# Altium schematic sheet SMPS.SchDoc
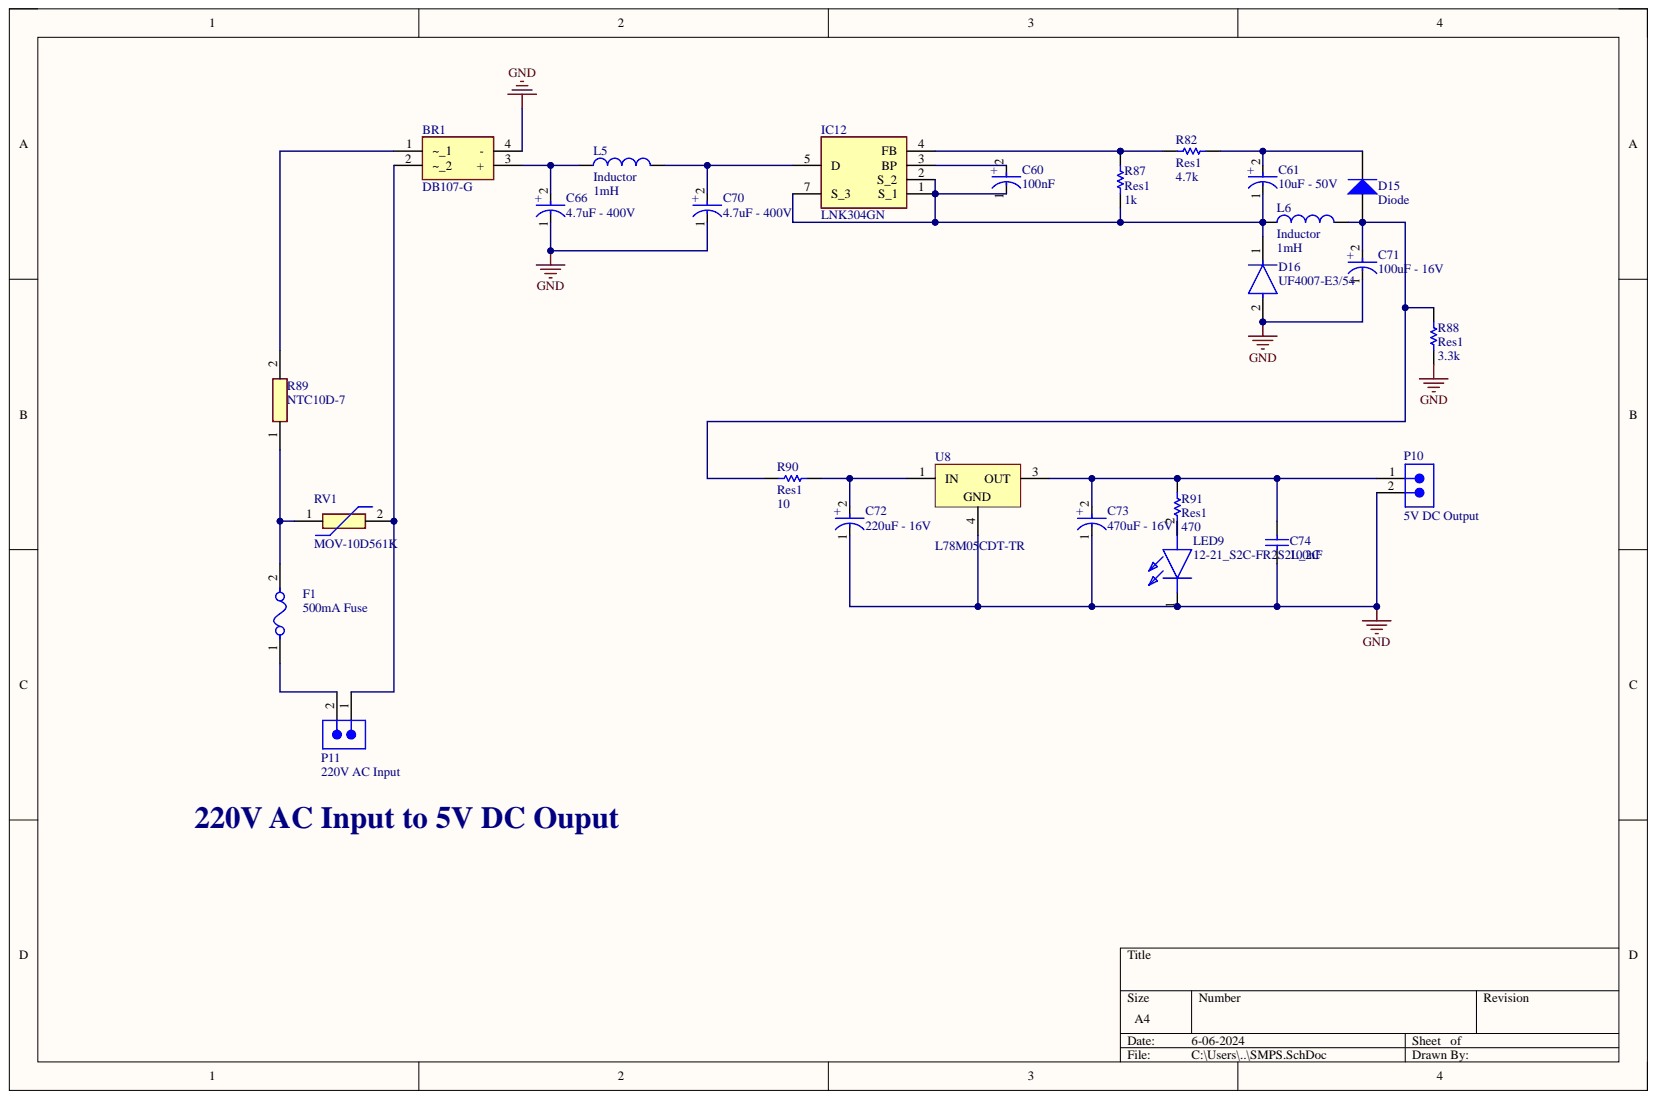
[diagram p0: SMPS.SchDoc]

## Parts
BR1  DB107-G  [DIP815W55P510L850H340Q4N]
C60  100nF  [FP-SC-MFG]
C61  10uF - 50V  Capacitance: 10uF  [FP-FKD-MFG]
C66  4.7uF - 400V  Capacitance: 4.7uF  [FP-RAD-TH-D_10_0_5-L_16_1-MFG]
C70  4.7uF - 400V  Capacitance: 4.7uF  [FP-RAD-TH-D_10_0_5-L_16_1-MFG]
C71  100uF - 16V  [FP-FPB-MFG]
C72  220uF - 16V  Capacitance: 220uF  [FP-RAD-TH-D_8_0_5-L_11_5-MFG]
C73  470uF - 16V  Capacitance: 470uF  [FP-FPF-MFG]
C74  100nF  [WCAP-ASNP_D4H5.5]
D15  Diode  [SMC]
D16  UF4007-E3/54  [FP-UF4007-MFG]
F1  500mA Fuse  [FP-0154-MFG]
IC12  LNK304GN  [G-PACKAGE(SMD-8B)_1]
L5  Inductor  value 1mH  [0402-A]
L6  Inductor  value 1mH  [0402-A]
LED9  12-21_S2C-FR2S2L_2C  [1221S2CFR2S2L2C]
P10  5V DC Output  [620302124022]
P11  220V AC Input  [620302124022]
R82  Res1  value 4.7k  [AXIAL-0.3]
R87  Res1  value 1k  [AXIAL-0.3]
R88  Res1  value 3.3k  [AXIAL-0.3]
R89  NTC10D-7  [NTC10D7]
R90  Res1  value 10  [AXIAL-0.3]
R91  Res1  value 470  [AXIAL-0.3]
RV1  MOV-10D561K  [MOV10D561K]
U8  L78M05CDT-TR  [TO-252_L]

## Nets
GND: BR1.4(-) C66.1 C70.1 C71.1 C72.1 C73.1 C74.1 D16.2 LED9.1 P10.2 R88.1 U8.4
NetBR1_1: BR1.1(~_1) R89.2
NetBR1_2: BR1.2(~_2) P11.1 RV1.2
NetBR1_3: BR1.3(+) C66.2 L5.1
NetC60_1: C60.1 C61.1 D16.1 IC12.1(S_1) IC12.2(S_2) IC12.7(S_3) L6.1 R87.1
NetC60_2: C60.2 IC12.3(BP)
NetC61_2: C61.2 D15.2 R82.2
NetC70_2: C70.2 IC12.5(D) L5.2
NetC71_2: C71.2 D15.1 L6.2 R88.2 R90.2
NetC72_2: C72.2 R90.1 U8.1
NetC73_2: C73.2 C74.2 P10.1 R91.1 U8.3
NetF1_1: F1.1 P11.2
NetF1_2: F1.2 R89.1 RV1.1
NetIC12_4: IC12.4(FB) R82.1 R87.2
NetLED9_2: LED9.2 R91.2

## Library data of the parts
BR1 = DB107-G: Bridge Rectifier; Manufacturer_Name: Comchip Technology; Arrow Part Number: DB107-G; Arrow Price/Stock: https://www.arrow.com/en/products/db107-g/comchip-technology?region=nac; Component Kind: Standard; Datasheet Link: https://datasheet.datasheetarchive.com/originals/distributors/Datasheets-DGA18/326891.pdf; Height: 3.4mm; Manufacturer_Part_Number: DB107-G; Mouser Part Number: 750-DB107-G; Mouser Price/Stock: https://www.mouser.co.uk/ProductDetail/Comchip-Technology/DB107-G?qs=2qJf6qQ4IOJFd%2FXSP%252BNqrw%3D%3D
C60 = CMP-05427-000047-1: CAP ALUM 100UF 20% 10V SMD; Life (Hours): 1000Hour; Ripple Current: 50mA; Capacitance: 100uF; Case/Package: Radial; Component Kind: Standard; Construction: Cylindrical; Contact Plating: Tin; Diameter: 5mm; Height: 5.4mm; Height - Seated (Max): 0.213inch; Lead Free: Lead Free; Length: 5.5mm; Library Name: Altium Content Vault; Max Operating Temperature: 85°C; Min Operating Temperature: -40°C; Mount: Surface Mount; Package Quantity: 1; Packaging: Tape and Reel; Radiation Hardening: No; Ripple Current (AC): 50mA; RoHS Compliant: Yes; Series: S; Termination: SMD/SMT; Tolerance: 20%; Voltage Rating: 10V; Voltage Rating (DC): 10V
C61 = CMP-2000-06207-2: CAP ALUM 10UF 20% 50V SMD; ESR (Equivalent Series Resistance): 880mR; Lead Diameter: 0.65mm; Leakage Current: 3uA; Life (Hours): 2000Hour; Polarity: Polar; Ripple Current: 165mA; Case/Package: Radial; Component Kind: Standard; Construction: Cylindrical; Contact Plating: Tin; Diameter: 6.3mm; Height: 5.8mm; Height - Seated (Max): 0.24inch; Impedance: 880mR; Lead Free: Lead Free; Lead Pitch: 1.8mm; Length: 5.8mm; Library Name: Altium Content Vault; Max Operating Temperature: 105°C; Min Operating Temperature: -55°C; Mount: Surface Mount; Number of Pins: 2; Package Quantity: 1000; Packaging: Tape and Reel; Radiation Hardening: No; REACH SVHC: No SVHC; Ripple Current (AC): 165mA; RoHS Compliant: Yes; Series: FK; Size: 5.8mm; Termination: SMD/SMT; Tolerance: 20%; Voltage: 50V; Voltage Rating: 50V; Voltage Rating (DC): 50V; Width: 7.8mm
C66 C70 = CMP-05427-000266-1: Aluminum Electrolytic Capacitors (Radial Lead Type) 4.7uF ±20% 400V; Dielectric Material: Aluminium; Dissipation Factor: 0.2%; Lead Diameter: 0.6mm; Lead Length: 17mm; Lead/Base Style: Straight; Leakage Current: 122.8uA; Life (Hours): 2000Hour; Polarity: Polar; Ripple Current: 72mA; Case/Package: Radial; Component Kind: Standard; Construction: RADIAL; Diameter: 10mm; Height: 16mm; Height - Seated (Max): 0.669inch; Lead Pitch: 5mm; Length: 16mm; Library Name: Altium Content Vault; Max Operating Temperature: 85°C; Min Operating Temperature: -25°C; Mount: Through Hole; Number of Pins: 2; Package Quantity: 200; Packaging: Bulk; Radiation Hardening: No; REACH SVHC: No SVHC; Ripple Current (AC): 72mA; RoHS Compliant: Yes; Series: M; Termination: Radial; Tolerance: 20%; Voltage: 400V; Voltage Rating: 400V; Voltage Rating (DC): 400V
C71 = CMP-00287-07742837-1: EEEFP1C100AR Aluminum Electrolytic Capacitor, 10 uF, 16 V, ± 20%, 2000 hours @ 105°C, Radial Can - SMD; Capacitance: 10µF; Dissipation Factor: 16%; ESR (Equivalent Series Resistance): 850mΩ; Leakage Current: 3µA; Material: Aluminium; Ripple Current: 160mA; Case/Package: Radial; Component Kind: Standard; Contact Plating: Tin; Diameter: 4mm; Features: High Temperature; Height: 5.8mm; Height - Seated (Max): 5.7912mm; Lead Free: Lead Free; Lead Pitch: 1mm; Length: 6.1mm; Library Name: Altium Content Vault; Life (Hours): 2000hours; Lifecycle Status: Production; Max Operating Temperature: 105°C; Min Operating Temperature: -55°C; Mount: PCB, Surface Mount; NexarPartId: 7742837; Number of Pins: 2; Packaging: Cut Tape; Radiation Hardening: No; REACH SVHC: No SVHC; Ripple Current (AC): 160mA; RoHS: Compliant; Schedule B: 8532220020, 8532220020; Termination: SMD/SMT; Tolerance: 20%; Voltage: 16V; Voltage Rating: 16V; Voltage Rating (DC): 16V
C72 = CMP-05427-002014-1: Aluminum Electrolytic Capacitors (Radial Lead Type) AEC-Q200 Qualified 220uF ±20% 16V; Impedance: 117mR; Lead Diameter: 0.6mm; Life (Hours): 2000Hour; Polarity: Polar; Ripple Current: 555mA; Case/Package: Radial; Component Kind: Standard; Diameter: 8mm; Height: 11.5mm; Height - Seated (Max): 0.492inch; Lead Pitch: 5mm; Length: 11mm; Library Name: Altium Content Vault; Max Operating Temperature: 105°C; Min Operating Temperature: -55°C; Mount: Through Hole; Package Quantity: 1000; Packaging: Ammo Pack; Radiation Hardening: No; Ratings: AEC-Q200; RoHS Compliant: Yes; Series: FC; Termination: Radial; Tolerance: 20%; Voltage Rating: 16V; Voltage Rating (DC): 16V
C73 = CMP-05427-002187-1: CAP ALUM 470UF 20% 16V SMD; ESR (Equivalent Series Resistance): 80mR; Leakage Current: 75.2uA; Life (Hours): 2000Hour; Material: Aluminium; Ripple Current: 850mA; Case/Package: Radial; Component Kind: Standard; Construction: Cylindrical; Contact Plating: Tin; Diameter: 8mm; Height: 10.2mm; Height - Seated (Max): 0.402inch; Lead Free: Lead Free; Lead Pitch: 3.1mm; Length: 10.5mm; Library Name: Altium Content Vault; Max Operating Temperature: 105°C; Min Operating Temperature: -55°C; Mount: Surface Mount; Number of Pins: 2; Package Quantity: 1; Packaging: Tape and Reel; Radiation Hardening: No; Reach SVHC Compliant: Yes; Ripple Current (AC): 850mA; RoHS Compliant: Yes; Series: FP; Termination: SMD/SMT; Tolerance: 20%; Voltage: 16V; Voltage Rating: 16V; Voltage Rating (DC): 16V; Width: 8.3mm
C74 = CMP-03913-53758718-1: 865250640001 WCAP-ASNP Aluminum Electrolytic Capacitors; Impedance: 20.6814Ω; Insulation Resistance: 16.6667MΩ; Leakage Current: 10µA; Life (Hours): 2000hours; Polarity: Polar; Ripple Current: 1mA; Tolerance: 20%; Voltage Rating: 50V; Capacitance: 100nF; Case/Package: Radial; Component Kind: Standard; Diameter: 4mm; Height: 5.5mm; Height - Seated (Max): 5.5118mm; Length: 5.5mm; Library Name: Altium Content Vault; Max Operating Temperature: 85°C; Min Operating Temperature: -40°C; Mount: Surface Mount; NexarPartId: 53758718; Packaging: Tape & Reel (TR); REACH SVHC: Unknown; Ripple Current (AC): 1mA; RoHS: Compliant; Voltage Rating (DC): 50V; Width: 4.3mm
D15 = Diode: Default Diode; Code_JEDEC: DO-214-AB; Component Kind: Standard; LatestRevisionDate: 17-Jul-2002; LatestRevisionNote: Re-released for DXP Platform.; Library Name: Miscellaneous Devices.IntLib; PackageDescription: DO-214-AB/SMC; 2 C-Bend Leads; Body 7.9 x 5.9 mm, inc. leads (LxW); PackageReference: SMC; PackageVersion: Sep-1996; Published: 8-Jun-2000; Signal Integrity: Diode; Simulation: DIODE; Publisher: Altium Limited
D16 = CMP-02540-000043-1: DIODE GEN PURP 1KV 1A DO204AL; Manufacturer: Vishay; Capacitance: 17pF; Max Junction Temp: +150°C; Max Repetitive Reverse Voltage: 1000V; Max Surge Forward Current: 30A; Peak Reverse Current: 10uA; Value: 17pF; Automotive: No; Category: Diode; Component Kind: Standard; ComponentLink2Description: Datasheet; ComponentLink2URL: http://www.vishay.com/docs/88755/uf4001.pdf; Device Class L1: Discrete Semiconductors; Device Class L2: Diodes; Device Class L3: Rectifier Diodes; Digikey Description: DIODE GEN PURP 1KV 1A DO204AL; Forward Current: 1A; Height: 2.7mm; Ipc Land Pattern Name: DIOPA1088W105L520D270; Lead Free: Yes; Library Name: Altium Content Vault; Manufacturer Part Number: UF4007-E3/54; Mouser Description: Rectifiers Vr/1000V Io/1A; Octopart: https://octopart.com/uf4007-e3%2F54-vishay-42333894; Package: UF4007; RoHS: TRUE; Temperature: -55°C to +150°C; Temperature Range High: +150°C; Temperature Range Low: -55°C
F1 = CMP-08605-000021-1: FUSE BOARD MNT 500MA 125VAC/VDC; Manufacturer: Littelfuse; Manufacturer Part Number: 0154.500DRT; Fuse Blow Speed: Slow; Fuse Current: 0.5A; Fuse I2T: 0.24A2Sec; Max Fuse Ac Voltage: 125V; Automotive: No; Category: Fuse; Component Kind: Standard; ComponentLink2Description: Datasheet; ComponentLink2URL: https://www.littelfuse.com/~/media/electronics/datasheets/fuses/littelfuse_fuse_154_154t_154l_154tl_datasheet.pdf.pdf; Device Class L1: Circuit Protection; Device Class L2: Fuses; Device Class L3: unset; Digikey Description: FUSE BOARD MNT 500MA 125VAC/VDC; Height: 3.81mm; Lead Free: Yes; Library Name: Altium Content Vault; Max Fuse Dc Voltage: 125V; Mouser Description: Surface Mount Fuses Fuseblck w/ fuse .5A OMNI BLOK 154T; Octopart: https://octopart.com/0154.500drt-littelfuse-565915; Package: 0154; RoHS: TRUE; Temperature: -55°C to +125°C; Temperature Range High: +125°C; Temperature Range Low: -55°C
IC12 = LNK304GN: Integrated Circuit; Manufacturer_Name: Power Integrations; Component Kind: Standard; Datasheet Link: https://www.power.com/sites/default/files/product-docs/lnk302_304-306.pdf; Manufacturer_Part_Number: LNK304GN; Mouser Part Number: 869-LNK304GN; Mouser Price/Stock: https://www.mouser.co.uk/ProductDetail/Power-Integrations/LNK304GN?qs=Jph8NoUxIfWAnpfQOKd5ww%3D%3D
L5 L6 = Inductor: Code_IEC: 1005; Value: 1mH; Code_JEITA: 0402; Component Kind: Standard; Description: Inductor; LatestRevisionDate: 29-May-2009; LatestRevisionNote: IPC-7351 Footprint Added.; Library Name: Miscellaneous Devices.IntLib; PackageDescription: Chip Inductor; PackageReference: 0402-A; Published: 8-Jun-2000; Signal Integrity: Inductor; Simulation: INDUCTOR; Publisher: Altium Limited
LED9 = 12-21_S2C-FR2S2L_2C: LED; Manufacturer_Name: Everlight; Manufacturer_Part_Number: 12-21/S2C-FR2S2L/2C; Component Kind: Standard; Datasheet Link: https://datasheet.lcsc.com/szlcsc/1901141904_Everlight-Elec-12-21-S2C-FR2S2L-2C_C183856.pdf; Height: 2.2mm; Simulation: L_Inductor_Default
P10 P11 = CMP-1502-00660-2: NexarPartId: 18818242; Connector Type: Male Pin; Contact Resistance: 20mΩ; Current Rating: 2A; Gender: Male; Insulation: Insulated; Insulation Resistance: 1GΩ; Number of Contacts: 2; Operating Supply Voltage: 100V; Pitch: 2mm; Voltage Rating: 125V; Color: Beige, White; Component Kind: Standard; Contact Gender: Male; Contact Material: Copper; Contact Mating Length: 3.5052mm; Contact Plating: Tin; Flammability Rating: UL94 V-0; Height: 6.6mm; Housing Material: Nylon; Lead Pitch: 2mm; Length: 5mm; Library Name: Altium Content Vault; Max Operating Temperature: 85°C; Min Operating Temperature: -40°C; Mount: Surface Mount; Number of Pins: 2; Number of Positions: 2; Number of Rows: 1; Orientation: Vertical; Packaging: Tape and Reel; REACH SVHC: Unknown; RoHS: Compliant; Termination: SMD/SMT; Width: 7.9mm
R82 R87 R88 R90 R91 = Res1: Resistor; Component Kind: Standard; LatestRevisionDate: 17-Jul-2002; LatestRevisionNote: Re-released for DXP Platform.; Library Name: Miscellaneous Devices.IntLib; PackageDescription: Axial Device, Thru-Hole; 2 Leads; 0.3 in Pin Spacing; PackageReference: AXIAL-0.3; Published: 8-Jun-2000; Signal Integrity: Res; Simulation: RESISTOR; Publisher: Altium Limited
R89 = NTC10D-7: Resistor; Manufacturer_Name: NTC; Component Kind: Standard; Datasheet Link: https://www.lcsc.com/datasheet/lcsc_datasheet_2403080959_RUILON-Shenzhen-Ruilongyuan-Elec-NTC10D-7_C9176.pdf; Height: 13mm; Manufacturer_Part_Number: NTC10D-7
RV1 = MOV-10D561K: Varistor; Manufacturer_Name: Bourns; Arrow Part Number: MOV-10D561K; Arrow Price/Stock: null?region=nac; Component Kind: Standard; Datasheet Link: https://www.bourns.com/docs/Product-Datasheets/MOV10D.pdf; Height: 15.5mm; Manufacturer_Part_Number: MOV-10D561K; Mouser Part Number: 652-MOV-10D561K; Mouser Price/Stock: https://www.mouser.co.uk/ProductDetail/Bourns/MOV-10D561K?qs=lgttKnAd%252B2ArrqGQgWwr6w%3D%3D
U8 = CMP-0244-00178-1: Positive Voltage Regulator, 5V, 3-Pin DPAK, Tape and Reel; Component Kind: Standard; ComponentLink1Description: Manufacturer URL; ComponentLink1URL: http://www.st.com; ComponentLink2Description: Datasheet; ComponentLink2URL: http://www.st.com/internet/com/TECHNICAL_RESOURCES/TECHNICAL_LITERATURE/DATASHEET/CD00000447.pdf; DatasheetVersion: 1/11/2010; Library Name: Altium Content Vault; PackageDescription: 3-Pin Transistor Outline Package 2.28 mm Pitch, 9.7 mm Lead Span (DPAK); PackageReference: TO-252; PackageVersion: 2011
